# Altium schematic sheet Gmsl2_des1.SchDoc
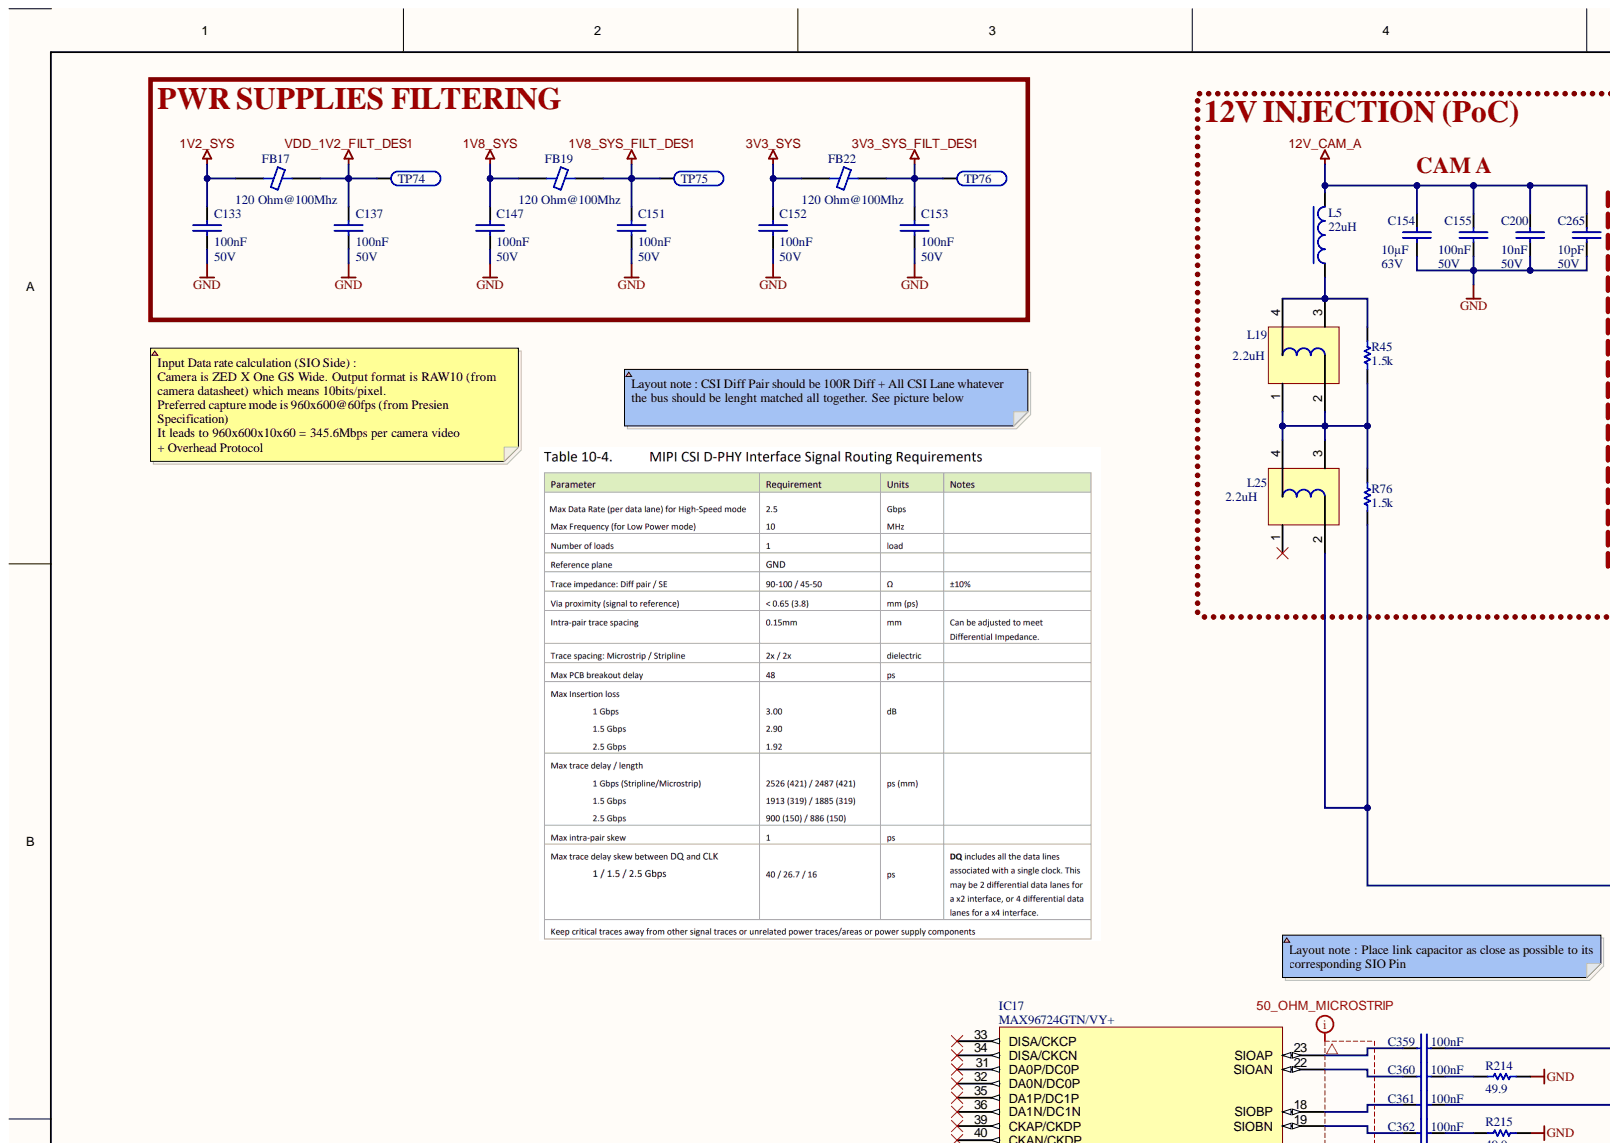
[diagram p0: Gmsl2_des1.SchDoc, top left]
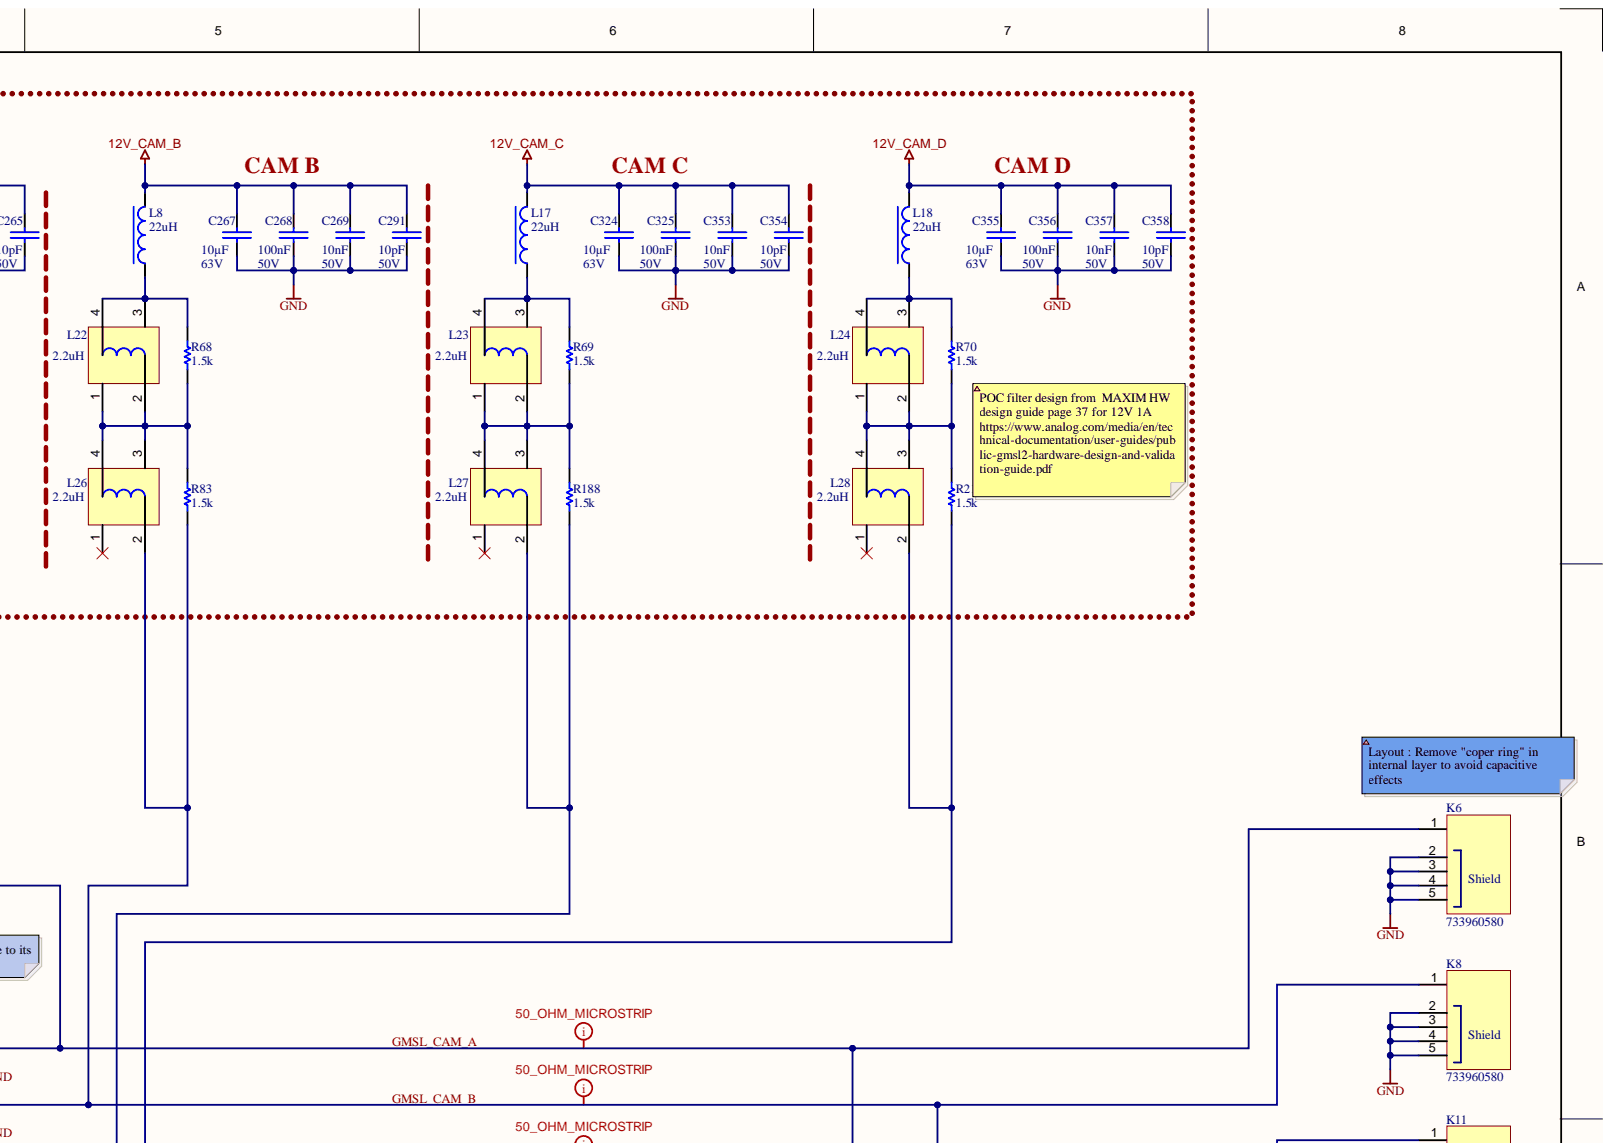
[diagram p1: Gmsl2_des1.SchDoc, top right]
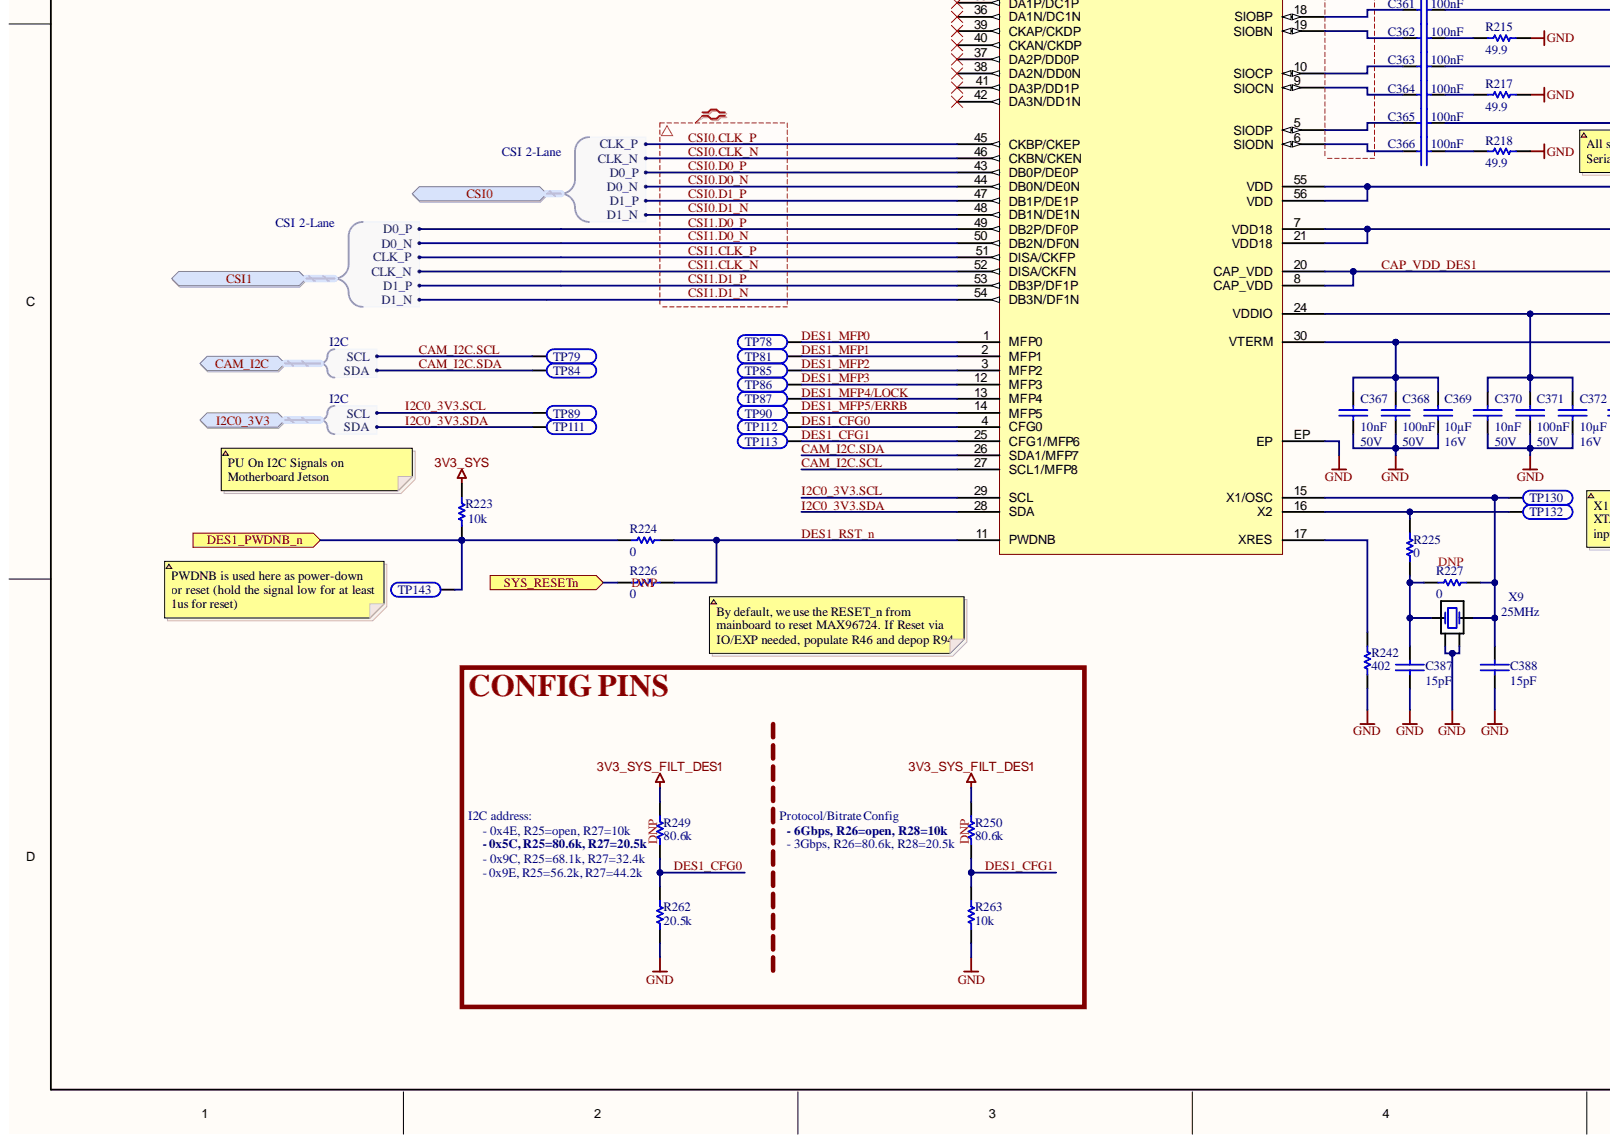
[diagram p2: Gmsl2_des1.SchDoc, bottom left]
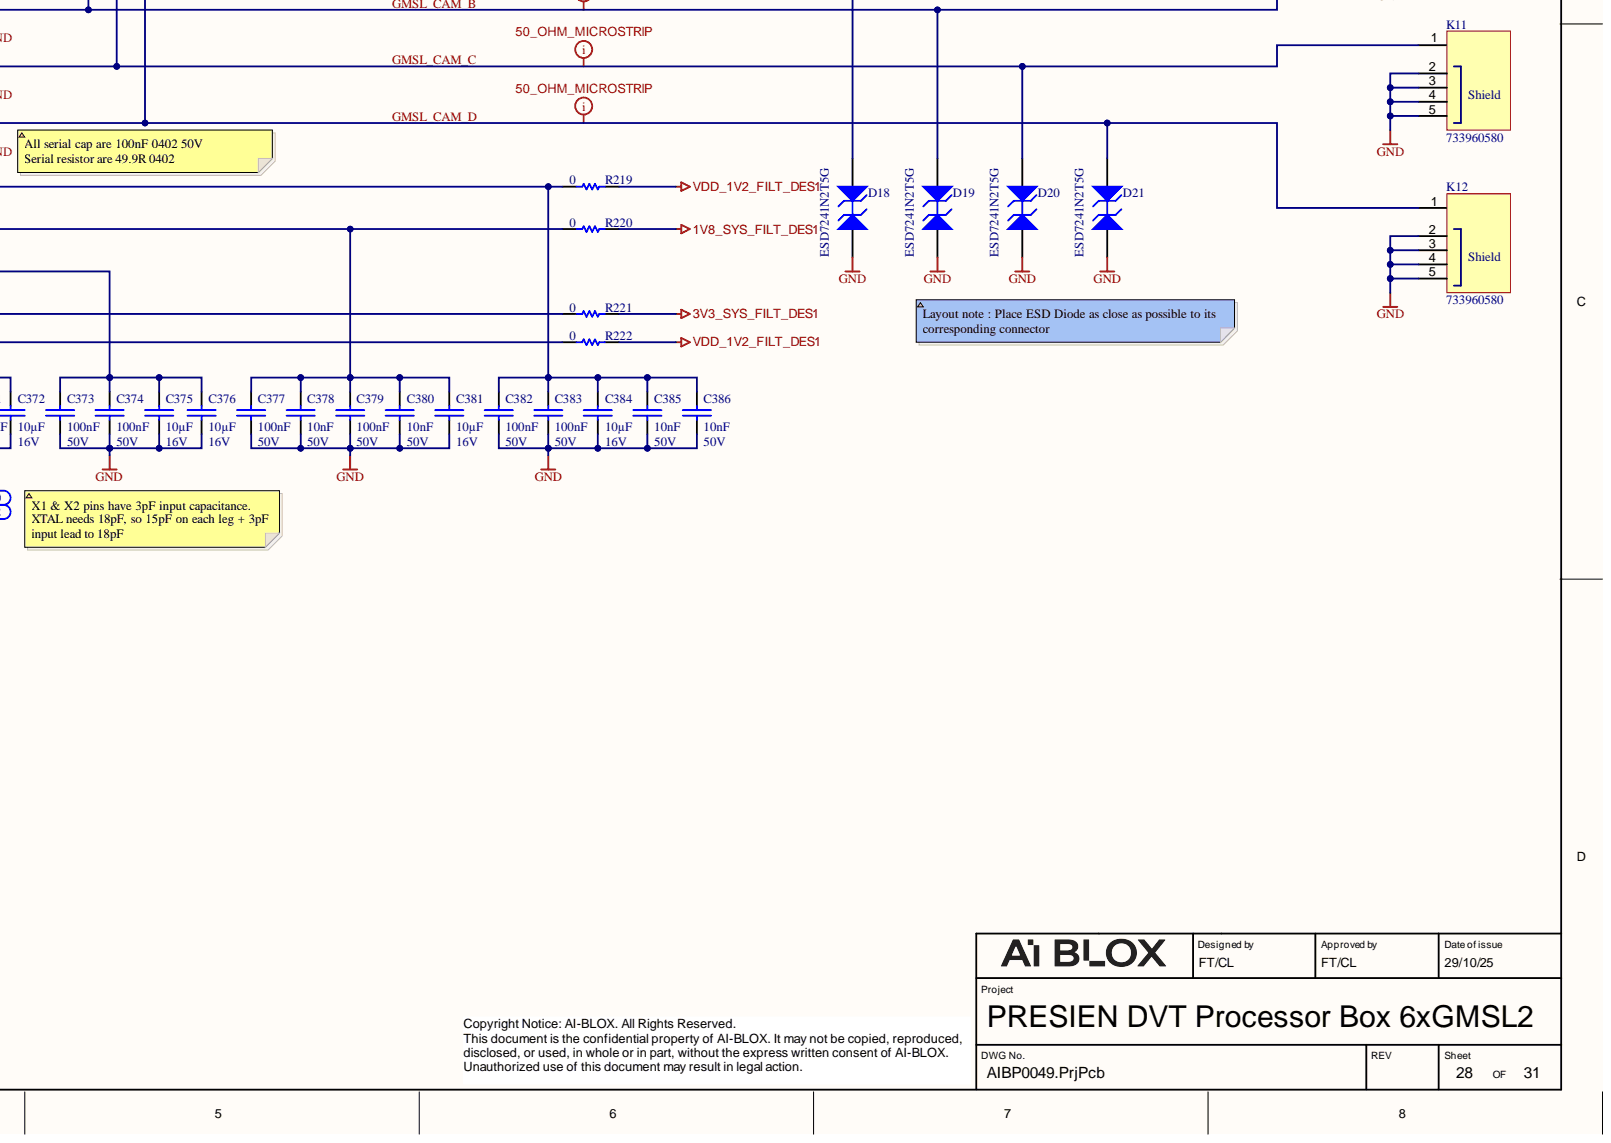
[diagram p3: Gmsl2_des1.SchDoc, bottom right]

## Parts
C133  value 100nF  Voltage: 50V  [CAP0603-M]  (hidden comment: CC0603KPX7R9BB104)
C137  value 100nF  Voltage: 50V  [CAP0603-M]  (hidden comment: CC0603KPX7R9BB104)
C147  value 100nF  Voltage: 50V  [CAP0603-M]  (hidden comment: CC0603KPX7R9BB104)
C151  value 100nF  Voltage: 50V  [CAP0603-M]  (hidden comment: CC0603KPX7R9BB104)
C152  value 100nF  Voltage: 50V  [CAP0603-M]  (hidden comment: CC0603KPX7R9BB104)
C153  value 100nF  Voltage: 50V  [CAP0603-M]  (hidden comment: CC0603KPX7R9BB104)
C154  value 10µF  Voltage: 63V  [CAP1210-CAPC3225X27N]  (hidden comment: GRM32ER71J106KA12L)
C155  value 100nF  Voltage: 50V  [CAP0603-M]  (hidden comment: CC0603KPX7R9BB104)
C200  value 10nF  Voltage: 50V  [CAP0603-M]  (hidden comment: CC0603KRX7R9BB103)
C265  value 10pF  Voltage: 50V  [CAP0603-M]  (hidden comment: CL10C100CB8NNNC)
C267  value 10µF  Voltage: 63V  [CAP1210-CAPC3225X27N]  (hidden comment: GRM32ER71J106KA12L)
C268  value 100nF  Voltage: 50V  [CAP0603-M]  (hidden comment: CC0603KPX7R9BB104)
C269  value 10nF  Voltage: 50V  [CAP0603-M]  (hidden comment: CC0603KRX7R9BB103)
C291  value 10pF  Voltage: 50V  [CAP0603-M]  (hidden comment: CL10C100CB8NNNC)
C324  value 10µF  Voltage: 63V  [CAP1210-CAPC3225X27N]  (hidden comment: GRM32ER71J106KA12L)
C325  value 100nF  Voltage: 50V  [CAP0603-M]  (hidden comment: CC0603KPX7R9BB104)
C353  value 10nF  Voltage: 50V  [CAP0603-M]  (hidden comment: CC0603KRX7R9BB103)
C354  value 10pF  Voltage: 50V  [CAP0603-M]  (hidden comment: CL10C100CB8NNNC)
C355  value 10µF  Voltage: 63V  [CAP1210-CAPC3225X27N]  (hidden comment: GRM32ER71J106KA12L)
C356  value 100nF  Voltage: 50V  [CAP0603-M]  (hidden comment: CC0603KPX7R9BB104)
C357  value 10nF  Voltage: 50V  [CAP0603-M]  (hidden comment: CC0603KRX7R9BB103)
C358  value 10pF  Voltage: 50V  [CAP0603-M]  (hidden comment: CL10C100CB8NNNC)
C359  value 100nF  [CAP0402-M]  (hidden comment: GRM155C71H104KE19)
C360  value 100nF  [CAP0402-M]  (hidden comment: GRM155C71H104KE19)
C361  value 100nF  [CAP0402-M]  (hidden comment: GRM155C71H104KE19)
C362  value 100nF  [CAP0402-M]  (hidden comment: GRM155C71H104KE19)
C363  value 100nF  [CAP0402-M]  (hidden comment: GRM155C71H104KE19)
C364  value 100nF  [CAP0402-M]  (hidden comment: GRM155C71H104KE19)
C365  value 100nF  [CAP0402-M]  (hidden comment: GRM155C71H104KE19)
C366  value 100nF  [CAP0402-M]  (hidden comment: GRM155C71H104KE19)
C367  value 10nF  Voltage: 50V  [CAP0603-M]  (hidden comment: CC0603KRX7R9BB103)
C368  value 100nF  Voltage: 50V  [CAP0603-M]  (hidden comment: CC0603KPX7R9BB104)
C369  value 10µF  Voltage: 16V  [CAP0805-M]  (hidden comment: CGA4J1X5R1C106K125AC)
C370  value 10nF  Voltage: 50V  [CAP0603-M]  (hidden comment: CC0603KRX7R9BB103)
C371  value 100nF  Voltage: 50V  [CAP0603-M]  (hidden comment: CC0603KPX7R9BB104)
C372  value 10µF  Voltage: 16V  [CAP0805-M]  (hidden comment: CGA4J1X5R1C106K125AC)
C373  value 100nF  Voltage: 50V  [CAP0603-M]  (hidden comment: CC0603KPX7R9BB104)
C374  value 100nF  Voltage: 50V  [CAP0603-M]  (hidden comment: CC0603KPX7R9BB104)
C375  value 10µF  Voltage: 16V  [CAP0805-M]  (hidden comment: CGA4J1X5R1C106K125AC)
C376  value 10µF  Voltage: 16V  [CAP0805-M]  (hidden comment: CGA4J1X5R1C106K125AC)
C377  value 100nF  Voltage: 50V  [CAP0603-M]  (hidden comment: CC0603KPX7R9BB104)
C378  value 10nF  Voltage: 50V  [CAP0603-M]  (hidden comment: CC0603KRX7R9BB103)
C379  value 100nF  Voltage: 50V  [CAP0603-M]  (hidden comment: CC0603KPX7R9BB104)
C380  value 10nF  Voltage: 50V  [CAP0603-M]  (hidden comment: CC0603KRX7R9BB103)
C381  value 10µF  Voltage: 16V  [CAP0805-M]  (hidden comment: CGA4J1X5R1C106K125AC)
C382  value 100nF  Voltage: 50V  [CAP0603-M]  (hidden comment: CC0603KPX7R9BB104)
C383  value 100nF  Voltage: 50V  [CAP0603-M]  (hidden comment: CC0603KPX7R9BB104)
C384  value 10µF  Voltage: 16V  [CAP0805-M]  (hidden comment: CGA4J1X5R1C106K125AC)
C385  value 10nF  Voltage: 50V  [CAP0603-M]  (hidden comment: CC0603KRX7R9BB103)
C386  value 10nF  Voltage: 50V  [CAP0603-M]  (hidden comment: CC0603KRX7R9BB103)
C387  value 15pF  [CAP0603-M]  (hidden comment: CC0603JRNPO9BN150)
C388  value 15pF  [CAP0603-M]  (hidden comment: CC0603JRNPO9BN150)
D18  ESD7241N2T5G  [ONSEMI_X2DFN]
D19  ESD7241N2T5G  [ONSEMI_X2DFN]
D20  ESD7241N2T5G  [ONSEMI_X2DFN]
D21  ESD7241N2T5G  [ONSEMI_X2DFN]
FB17  value 120 Ohm@100Mhz  [FER0603]  (hidden comment: BLM18EG121SN1D)
FB19  value 120 Ohm@100Mhz  [FER0603]  (hidden comment: BLM18EG121SN1D)
FB22  value 120 Ohm@100Mhz  [FER0603]  (hidden comment: BLM18EG121SN1D)
IC17  MAX96724GTN/VY+  [ANALOG_56TQFN-SW-8x8]
K6  733960580  [733960580]
K8  733960580  [733960580]
K11  733960580  [733960580]
K12  733960580  [733960580]
L5  value 22uH  [INDP5350X30N]  (hidden comment: VLS5030EX-220M-D)
L8  value 22uH  [INDP5350X30N]  (hidden comment: VLS5030EX-220M-D)
L17  value 22uH  [INDP5350X30N]  (hidden comment: VLS5030EX-220M-D)
L18  value 22uH  [INDP5350X30N]  (hidden comment: VLS5030EX-220M-D)
L19  value 2.2uH  ["]  (hidden comment: ADL3225VM-2R2M-TL001)
L22  value 2.2uH  ["]  (hidden comment: ADL3225VM-2R2M-TL001)
L23  value 2.2uH  ["]  (hidden comment: ADL3225VM-2R2M-TL001)
L24  value 2.2uH  ["]  (hidden comment: ADL3225VM-2R2M-TL001)
L25  value 2.2uH  ["]  (hidden comment: ADL3225VM-2R2M-TL001)
L26  value 2.2uH  ["]  (hidden comment: ADL3225VM-2R2M-TL001)
L27  value 2.2uH  ["]  (hidden comment: ADL3225VM-2R2M-TL001)
L28  value 2.2uH  ["]  (hidden comment: ADL3225VM-2R2M-TL001)
R45  value 1.5k  [RES0402]  (hidden comment: RC0402FR-071K5L)
R68  value 1.5k  [RES0402]  (hidden comment: RC0402FR-071K5L)
R69  value 1.5k  [RES0402]  (hidden comment: RC0402FR-071K5L)
R70  value 1.5k  [RES0402]  (hidden comment: RC0402FR-071K5L)
R76  value 1.5k  [RES0402]  (hidden comment: RC0402FR-071K5L)
R83  value 1.5k  [RES0402]  (hidden comment: RC0402FR-071K5L)
R188  value 1.5k  [RES0402]  (hidden comment: RC0402FR-071K5L)
R213  value 1.5k  [RES0402]  (hidden comment: RC0402FR-071K5L)
R214  value 49.9  [RES0402]  (hidden comment: RC0402FR-0749R9P)
R215  value 49.9  [RES0402]  (hidden comment: RC0402FR-0749R9P)
R217  value 49.9  [RES0402]  (hidden comment: RC0402FR-0749R9P)
R218  value 49.9  [RES0402]  (hidden comment: RC0402FR-0749R9P)
R219  value 0  [RES0603]  (hidden comment: RC0603FR-070RL)
R220  value 0  [RES0603]  (hidden comment: RC0603FR-070RL)
R221  value 0  [RES0603]  (hidden comment: RC0603FR-070RL)
R222  value 0  [RES0603]  (hidden comment: RC0603FR-070RL)
R223  value 10k  [RES0603]  (hidden comment: RMCF0603FT10K0)
R224  value 0  [RES0603]  (hidden comment: RC0603FR-070RL)
R225  value 0  [RES0603]  (hidden comment: RC0603FR-070RL)
R226  value 0  [RES0603]  (hidden comment: RC0603FR-070RL)
R227  value 0  [RES0603]  (hidden comment: RC0603FR-070RL)
R242  value 402  [RES0603]  (hidden comment: RC0603FR-07402RL)
R249  value 80.6k  [RES0603]  (hidden comment: RC0603FR-0780K6L)
R250  value 80.6k  [RES0603]  (hidden comment: RC0603FR-0780K6L)
R262  value 20.5k  [RES0603]  (hidden comment: RC0603FR-0720K5L)
R263  value 10k  [RES0603]  (hidden comment: RC0603FR-0710KL)
TP74  Test_Point_1  [Test_Point_1]  (value not drawn)  (no BOM)
TP75  Test_Point_1  [Test_Point_1]  (value not drawn)  (no BOM)
TP76  Test_Point_1  [Test_Point_1]  (value not drawn)  (no BOM)
TP78  Test_Point_1  [Test_Point_1]  (value not drawn)  (no BOM)
TP79  Test_Point_1  [Test_Point_1]  (value not drawn)  (no BOM)
TP81  Test_Point_1  [Test_Point_1]  (value not drawn)  (no BOM)
TP84  Test_Point_1  [Test_Point_1]  (value not drawn)  (no BOM)
TP85  Test_Point_1  [Test_Point_1]  (value not drawn)  (no BOM)
TP86  Test_Point_1  [Test_Point_1]  (value not drawn)  (no BOM)
TP87  Test_Point_1  [Test_Point_1]  (value not drawn)  (no BOM)
TP89  Test_Point_1  [Test_Point_1]  (value not drawn)  (no BOM)
TP90  Test_Point_1  [Test_Point_1]  (value not drawn)  (no BOM)
TP111  Test_Point_1  [Test_Point_1]  (value not drawn)  (no BOM)
TP112  Test_Point_1  [Test_Point_1]  (value not drawn)  (no BOM)
TP113  Test_Point_1  [Test_Point_1]  (value not drawn)  (no BOM)
TP130  Test_Point_1  [Test_Point_1]  (value not drawn)  (no BOM)
TP132  Test_Point_1  [Test_Point_1]  (value not drawn)  (no BOM)
TP143  Test_Point_1  [Test_Point_1]  (value not drawn)  (no BOM)
X9  value 25MHz  [DIODES FL SERIE]  (hidden comment: FL2500133)

## Ports
CAM_I2C, CAM_I2C.SCL, CAM_I2C.SDA, CSI0, CSI0.CLK_N, CSI0.CLK_P, CSI0.D0_N, CSI0.D0_P, CSI0.D1_N, CSI0.D1_P, CSI1, CSI1.CLK_N, CSI1.CLK_P, CSI1.D0_N, CSI1.D0_P, CSI1.D1_N, CSI1.D1_P, DES1_PWDNB_n, I2C0_3V3, I2C0_3V3.SCL, I2C0_3V3.SDA, SYS_RESETn

## Nets
1V2_SYS: C133.1 FB17.1
1V8_SYS: C147.1 FB19.1
1V8_SYS_FILT_DES1: C151.1 FB19.2 R220.2 TP75.1
3V3_SYS: C152.1 FB22.1 R223.2
3V3_SYS_FILT_DES1: C153.1 FB22.2 R221.2 R249.2 R250.2 TP76.1
12V_CAM_A: C154.1 C155.1 C200.1 C265.1 L5.2
12V_CAM_B: C267.1 C268.1 C269.1 C291.1 L8.2
12V_CAM_C: C324.1 C325.1 C353.1 C354.1 L17.2
12V_CAM_D: C355.1 C356.1 C357.1 C358.1 L18.2
GND: C133.2 C137.2 C147.2 C151.2 C152.2 C153.2 C154.2 C155.2 C200.2 C265.2 C267.2 C268.2 C269.2 C291.2 C324.2 C325.2 C353.2 C354.2 C355.2 C356.2 C357.2 C358.2 C367.2 C368.2 C369.2 C370.2 C371.2 C372.2 C373.2 C374.2 C375.2 C376.2 C377.2 C378.2 C379.2 C380.2 C381.2 C382.2 C383.2 C384.2 C385.2 C386.2 C387.2 C388.2 D18.A D19.A D20.A D21.A IC17.EP K6.2 K6.3 K6.4 K6.5 K8.2 K8.3 K8.4(Shield) K8.5 K11.2 K11.3 K11.4 K11.5 K12.2 K12.3 K12.4 K12.5 R214.2 R215.2 R217.2 R218.2 R242.1 R262.1 R263.1 X9.2 X9.4
VDD_1V2_FILT_DES1: C137.1 FB17.2 R219.2 R222.2 TP74.1
CAM_I2C.SCL: IC17.27(SCL1/MFP8) TP79.1 ; port CAM_I2C
CAM_I2C.SDA: IC17.26(SDA1/MFP7) TP84.1 ; port CAM_I2C
CAP_VDD_DES1: C373.1 C374.1 C375.1 C376.1 IC17.8(CAP_VDD) IC17.20(CAP_VDD)
CSI0.CLK_N: IC17.46(CKBN/CKEN) ; port CSI0
CSI0.CLK_P: IC17.45(CKBP/CKEP) ; port CSI0
CSI0.D0_N: IC17.44(DB0N/DE0N) ; port CSI0
CSI0.D0_P: IC17.43(DB0P/DE0P) ; port CSI0
CSI0.D1_N: IC17.48(DB1N/DE1N) ; port CSI0
CSI0.D1_P: IC17.47(DB1P/DE1P) ; port CSI0
CSI1.CLK_N: IC17.52(DISA/CKFN) ; port CSI1
CSI1.CLK_P: IC17.51(DISA/CKFP) ; port CSI1
CSI1.D0_N: IC17.50(DB2N/DF0N) ; port CSI1
CSI1.D0_P: IC17.49(DB2P/DF0P) ; port CSI1
CSI1.D1_N: IC17.54(DB3N/DF1N) ; port CSI1
CSI1.D1_P: IC17.53(DB3P/DF1P) ; port CSI1
DES1_CFG0: IC17.4(CFG0) R249.1 R262.2 TP112.1
DES1_CFG1: IC17.25(CFG1/MFP6) R250.1 R263.2 TP113.1
DES1_MFP0: IC17.1(MFP0) TP78.1
DES1_MFP1: IC17.2(MFP1) TP81.1
DES1_MFP2: IC17.3(MFP2) TP85.1
DES1_MFP3: IC17.12(MFP3) TP86.1
DES1_MFP4/LOCK: IC17.13(MFP4) TP87.1
DES1_MFP5/ERRB: IC17.14(MFP5) TP90.1
DES1_PWDNB_n: R223.1 R224.2 TP143.1 ; port DES1_PWDNB_n
DES1_RST_n: IC17.11(PWDNB) R224.1 R226.1
GMSL_CAM_A: C359.2 D18.K K6.1 L25.2 R76.1
GMSL_CAM_B: C361.2 D19.K K8.1 L26.2 R83.1
GMSL_CAM_C: C363.2 D20.K K11.1 L27.2 R188.1
GMSL_CAM_D: C365.2 D21.K K12.1 L28.2 R213.1
I2C0_3V3.SCL: IC17.29(SCL) TP89.1 ; port I2C0_3V3
I2C0_3V3.SDA: IC17.28(SDA) TP111.1 ; port I2C0_3V3
SYS_RESETn: R226.2 ; port SYS_RESETn
NetC359_1: C359.1 IC17.23(SIOAP)
NetC360_1: C360.1 IC17.22(SIOAN)
NetC360_2: C360.2 R214.1
NetC361_1: C361.1 IC17.18(SIOBP)
NetC362_1: C362.1 IC17.19(SIOBN)
NetC362_2: C362.2 R215.1
NetC363_1: C363.1 IC17.10(SIOCP)
NetC364_1: C364.1 IC17.9(SIOCN)
NetC364_2: C364.2 R217.1
NetC365_1: C365.1 IC17.5(SIODP)
NetC366_1: C366.1 IC17.6(SIODN)
NetC366_2: C366.2 R218.1
NetC367_1: C367.1 C368.1 C369.1 IC17.30(VTERM) R222.1
NetC370_1: C370.1 C371.1 C372.1 IC17.24(VDDIO) R221.1
NetC377_1: C377.1 C378.1 C379.1 C380.1 C381.1 IC17.7(VDD18) IC17.21(VDD18) R220.1
NetC382_1: C382.1 C383.1 C384.1 C385.1 C386.1 IC17.55(VDD) IC17.56(VDD) R219.1
NetC387_1: C387.1 R225.1 R227.2 X9.3
NetC388_1: C388.1 IC17.15(X1/OSC) R227.1 TP130.1 X9.1
NetIC17_16: IC17.16(X2) R225.2 TP132.1
NetIC17_17: IC17.17(XRES) R242.2
NetL5_1: L5.1 L19.3 L19.4 R45.2
NetL8_1: L8.1 L22.3 L22.4 R68.2
NetL17_1: L17.1 L23.3 L23.4 R69.2
NetL18_1: L18.1 L24.3 L24.4 R70.2
NetL19_1: L19.1 L19.2 L25.3 L25.4 R45.1 R76.2
NetL22_1: L22.1 L22.2 L26.3 L26.4 R68.1 R83.2
NetL23_1: L23.1 L23.2 L27.3 L27.4 R69.1 R188.2
NetL24_1: L24.1 L24.2 L28.3 L28.4 R70.1 R213.2

Not connected: IC17 31(DA0P/DC0P) 32(DA0N/DC0P) 33(DISA/CKCP) 34(DISA/CKCN) 35(DA1P/DC1P) 36(DA1N/DC1N) 37(DA2P/DD0P) 38(DA2N/DD0N) 39(CKAP/CKDP) 40(CKAN/CKDP) 41(DA3P/DD1P) 42(DA3N/DD1N); L25 1; L26 1; L27 1; L28 1

## Library data of the parts
C133 C137 C147 C151-C153 C155 C268 C325 C356 C368 C371 C373 C374 C377 C379 C382 C383 = CMP-81.02.0001-14: CAP CER 0.1UF 50V X7R 0603; Manufacturer 1: YAGEO; GRADE: NO; Case/Package: 0603; Component Kind: Standard; DatasheetDocument: https://datasheet.octopart.com/CC0603KPX7R9BB104-Yageo-datasheet-151948686.pdf; Internal Ref: 81.02.0001; Manufacturer Part Number 1: CC0603KPX7R9BB104; ROHS: YES; Library Name: AI Blox; Publisher: Hans Stevens
C154 C267 C324 C355 = CMP-81.02.0009-8: CAP CER 10UF 63V X7R 1210; Manufacturer 1: Murata; GRADE: NO; Case/Package: 1210; Component Kind: Standard; DatasheetDocument: https://octopart.com/datasheet/grm32er71j106ka12l-murata-33513537; Internal Ref: 81.02.0009; Manufacturer Part Number 1: GRM32ER71J106KA12L; ROHS: YES; Library Name: AI Blox; Publisher: Hans Stevens
C200 C269 C353 C357 C367 C370 C378 C380 C385 C386 = CMP-81.02.0030-9: CAP CER 0.010UF 50V X7R 0603; Manufacturer 1: YAGEO; GRADE: NO; Case/Package: 0603; Component Kind: Standard; DatasheetDocument: https://octopart.com/datasheet/cc0603krx7r9bb103-yageo-192938; Internal Ref: 81.02.0030; Manufacturer Part Number 1: CC0603KRX7R9BB103; ROHS: YES; Library Name: AI Blox; Publisher: Marc Goovaerts
C265 C291 C354 C358 = CMP-81.02.0020-9: CAP CER 10PF 50V C0G/NP0 0603; Manufacturer 1: Samsung; GRADE: NO; Case/Package: 0603; Component Kind: Standard; DatasheetDocument: https://octopart.com/datasheet/cl10c100cb8nnnc-samsung-19018981; Internal Ref: 81.02.0020; Manufacturer Part Number 1: CL10C100CB8NNNC; ROHS: YES; Library Name: AI Blox; Publisher: Hans Stevens
C359-C366 = CMP-81.02.0039-10: CAP CER 0.1UF 50V X7S 0402; Manufacturer 1: Murata; GRADE: NO; Tolerance: 10%; Case/Package: 0402; Component Kind: Standard; DatasheetDocument: https://octopart.com/datasheet/grm155c71h104ke19d-murata-18783362; Internal Ref: 81.02.0039; Manufacturer Part Number 1: GRM155C71H104KE19; ROHS: YES; Voltage: 50V; Library Name: AI Blox; Publisher: Hans Stevens
C369 C372 C375 C376 C381 C384 = CMP-81.02.0005-14: CAP CER 10UF 16V X5R 0805; Manufacturer 1: TDK; GRADE: NO; Case/Package: 0805; Component Kind: Standard; DatasheetDocument: https://octopart.com/datasheet/cga4j1x5r1c106k125ac-tdk-26014647; Internal Ref: 81.02.0005; Manufacturer Part Number 1: CGA4J1X5R1C106K125AC; ROHS: YES; Library Name: AI Blox; Publisher: Hans Stevens
C387 C388 = CMP-81.02.0070-4: CAP CER 15PF 50V C0G/NPO 0603; Manufacturer 1: YAGEO; GRADE: NO; Tolerance: 5%; Case/Package: 0603; Component Kind: Standard; DatasheetDocument: https://octopart.com/datasheet/cc0603jrnpo9bn150-yageo-39467672; Internal Ref: 81.02.0070; Manufacturer Part Number 1: CC0603JRNPO9BN150; ROHS: YES; Voltage: 50V; Library Name: AI Blox
D18-D21 = CMP-81.09.0019-3: TVS DIODE 24VWM 48VC 2X2DFN; GRADE: NO; Case/Package: DFN2; Component Kind: Standard; DatasheetDocument: https://octopart.com/datasheet/esd7241n2t5g-onsemi-75535408; Internal Ref: 81.09.0019; ROHS: YES; Library Name: AI Blox; Publisher: Hans Stevens
FB17 FB19 FB22 = CMP-81.11.0002-2: FERRITE BEAD 120 OHM 0603 1LN; Manufacturer 1: Murata; GRADE: NO; Component Kind: Standard; DatasheetDocument: https://octopart.com/datasheet/blm18eg121sn1d-murata-368362; Internal Ref: 81.11.0002; Manufacturer Part Number 1: BLM18EG121SN1D; ROHS: YES; Supplier 1: Digikey; Supplier Part Number 1: 490-3991-1-ND; Library Name: AI Blox; Publisher: Hans Stevens
IC17 = CMP-81.04.0054-1: GMSL2/1 TUNNELING QUAD DESERIALI; Manufacturer 1: Analog; GRADE: NO; Component Kind: Standard; DatasheetDocument: https://www.analog.com/en/products/max96724.html?ADICID=SYND_WW_P682800_PF-octopart; Internal Ref: 81.04.0054; Manufacturer Part Number 1: MAX96724GTN/VY+; Package: 56-TQFN; ROHS: YES; Supplier 1: Mouser; Supplier Part Number 1: 700-MAX96724GTN/VY+; Library Name: AI Blox; Publisher: Hans Stevens
K6 K8 K11 K12 = CMP-81.03.0156-7: SMB R/A PCB RECEPTACLE; GRADE: NO; Component Kind: Standard; DatasheetDocument: https://octopart.com/73396-0580-molex-101557221; Internal Ref: 81.03.0156; ROHS: YES; Library Name: AI Blox; Publisher: Jeroen Wallyn
L5 L8 L17 L18 = CMP-81.11.0049-1: INDUCTORS FOR POWER CIRCUITS, AU; Manufacturer 1: TDK; GRADE: YES; Component Kind: Standard; DatasheetDocument: https://octopart.com/vls5030ex-220m-d-tdk-132322332; Internal Ref: 81.11.0049; Manufacturer Part Number 1: VLS5030EX-220M-D; ROHS: YES; Library Name: AI Blox; Publisher: Jeroen Wallyn
L19 L22-L28 = CMP-81.11.0048-2: FIXED IND 2.2UH 1.22A 180MOHM SM; Manufacturer 1: TDK; GRADE: YES; Component Kind: Standard; DatasheetDocument: https://octopart.com/adl3225vm-2r2m-tl001-tdk-134204157; Internal Ref: 81.11.0048; Manufacturer Part Number 1: FIXED IND 2.2UH 1.22A 180MOHM SM; ROHS: YES; Library Name: AI Blox; Publisher: Jeroen Wallyn
R45 R68-R70 R76 R83 R188 R213 = CMP-81.01.0208-1: RES 1.5K OHM 1% 1/16W 0402; GRADE: NO; Case/Package: 0402; Component Kind: Standard; DatasheetDocument: https://www.yageogroup.com/content/datasheet/asset/file/PYU-RC_GROUP_51_ROHS_L; Internal Ref: 81.01.0208; ROHS: YES; Library Name: AI Blox; Publisher: FT
R214 R215 R217 R218 = CMP-81.01.0067-5: RES SMD 49.9 OHM 1% 1/16W 0402; GRADE: NO; Case/Package: 0402; Component Kind: Standard; DatasheetDocument: https://octopart.com/datasheet/rc0402fr-0749r9p-yageo-47616041; Internal Ref: 81.01.0067; ROHS: YES; Library Name: AI Blox; Publisher: Hans Stevens
R219-R222 R224-R227 = CMP-81.01.0005-5: RES 0 OHM JUMPER 1/10W 0603; GRADE: NO; Case/Package: 0603; Component Kind: Standard; DatasheetDocument: https://octopart.com/datasheet/rc0603fr-070rl-yageo-1180803; Internal Ref: 81.01.0005; ROHS: YES; Library Name: AI Blox; Publisher: Hans Stevens
R223 = CMP-81.08.0001-1: RES 10K OHM 1% 1/10W 0603; Manufacturer 1: Stackpole Electronics Inc; GRADE: AEC-Q200; Case/Package: 0603; Component Kind: Standard; DatasheetDocument: https://datasheet.octopart.com/RMCF0603FT10K0-Stackpole-Electronics-datasheet-141193804.pdf; Internal Ref: 81.08.0001; Manufacturer Part Number 1: RMCF0603FT10K0; ROHS: YES; Library Name: AI Blox; Publisher: Hans Stevens
R242 = CMP-81.01.0066-5: RES 402 OHM 1% 1/10W 0603; GRADE: NO; Case/Package: 0603; Component Kind: Standard; DatasheetDocument: https://octopart.com/datasheet/rc0603fr-07402rl-yageo-1201043; Internal Ref: 81.01.0066; ROHS: YES; Library Name: AI Blox; Publisher: Hans Stevens
R249 R250 = CMP-81.01.0069-5: RES 80K6 OHM 1% 1/10W 0603; GRADE: NO; Case/Package: 0603; Component Kind: Standard; DatasheetDocument: https://octopart.com/datasheet/rc0603fr-0780k6l-yageo-1194262; Internal Ref: 81.01.0069; ROHS: YES; Library Name: AI Blox; Publisher: Marc Goovaerts
R262 = CMP-81.01.0068-5: RES 20K5 OHM 1% 1/10W 0603; GRADE: NO; Case/Package: 0603; Component Kind: Standard; DatasheetDocument: https://octopart.com/datasheet/rc0603fr-0720k5l-yageo-1227915; Internal Ref: 81.01.0068; ROHS: YES; Library Name: AI Blox; Publisher: Marc Goovaerts
R263 = CMP-81.01.0021-5: RES 10K OHM 1% 1/10W 0603; GRADE: NO; Case/Package: 0603; Component Kind: Standard; DatasheetDocument: https://octopart.com/datasheet/rc0603fr-0710kl-yageo-40025538; Internal Ref: 81.01.0021; ROHS: YES; Library Name: AI Blox; Publisher: Hans Stevens
TP74-TP76 TP78 TP79 TP81 TP84-TP87 TP89 TP90 TP111-TP113 TP130 TP132 TP143 = CMP-81.00.0006-9: 0.8mm testpoint; Library Name: AI Blox
X9 = CMP-81.12.0001-6: CRYSTAL 25.0000MHZ 18PF SMD; Manufacturer 1: Diodes Inc.; GRADE: NO; Component Kind: Standard; DatasheetDocument: https://www.diodes.com/assets/Datasheets/FL.pdf; Internal Ref: 81.12.0001; Manufacturer Part Number 1: FL2500133; ROHS: YES; Supplier 1: Digikey; Supplier Part Number 1: FL2500133CT-ND; Library Name: AI Blox; Publisher: Hans Stevens
